# Altium schematic sheet Power.SchDoc(Power)
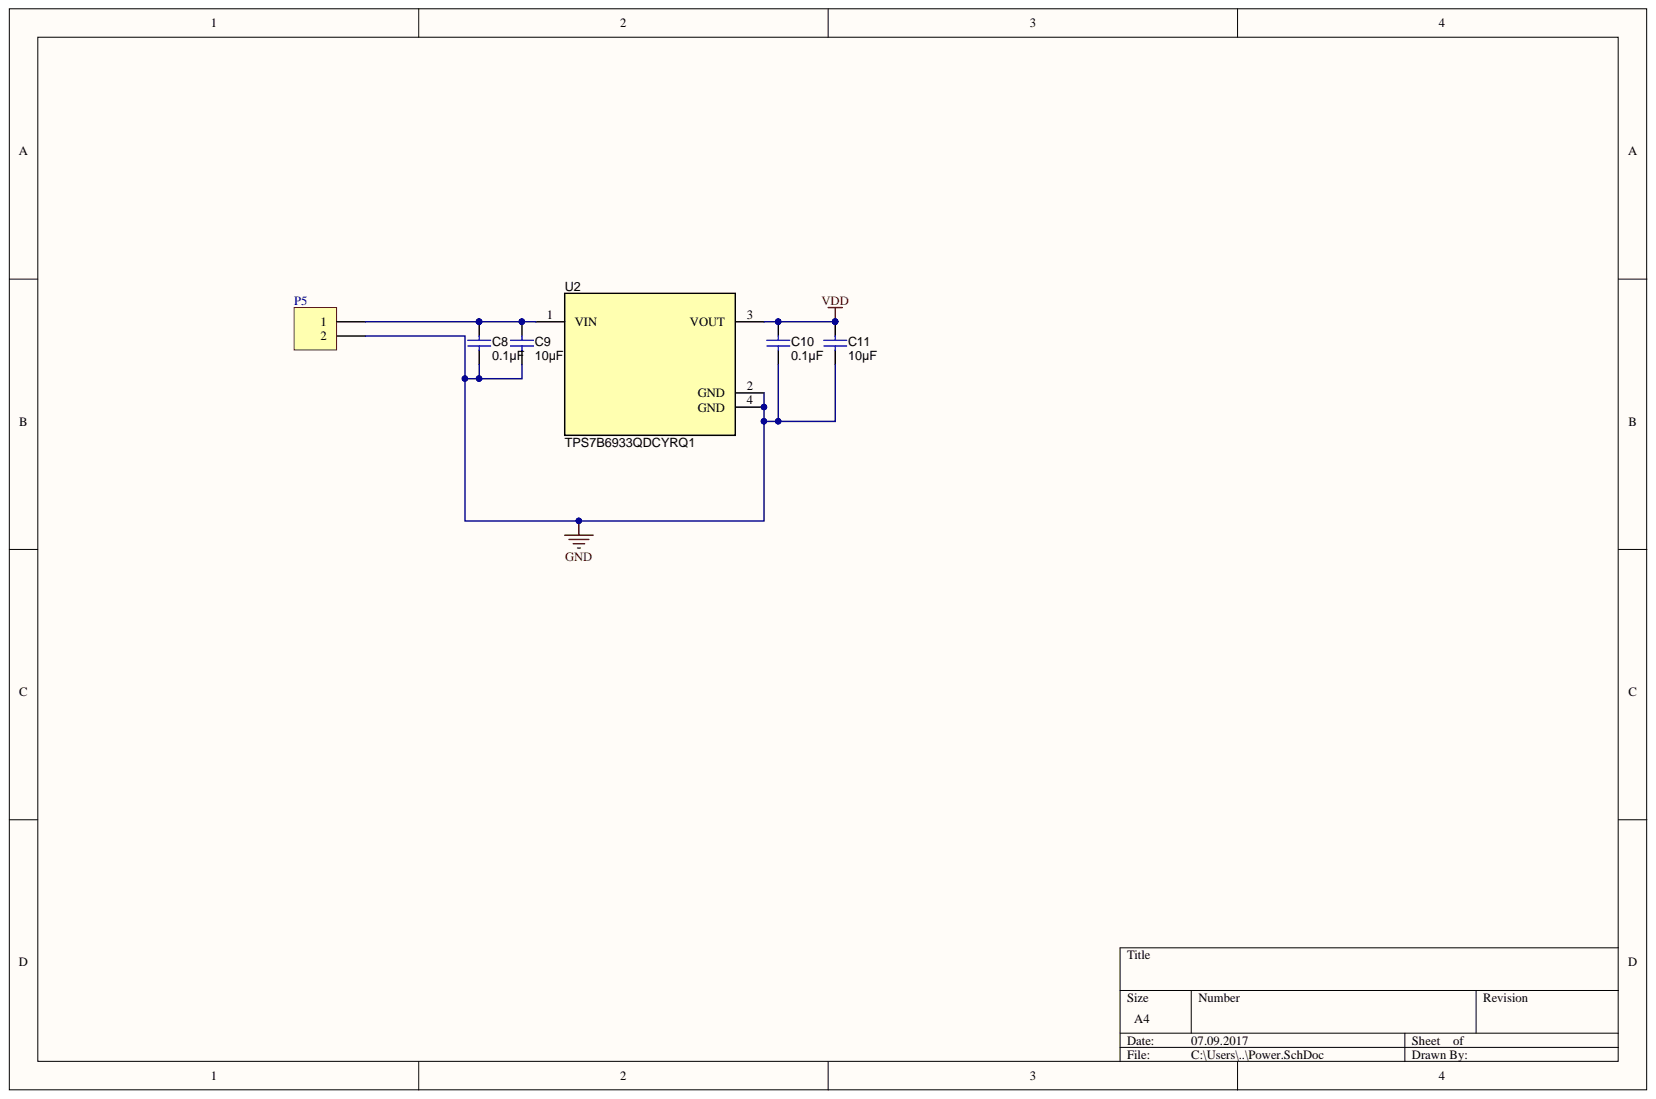
[diagram p0: Power.SchDoc]

## Parts
C8  ValueDisplayed: 0.1µF  [0603L]  (hidden comment: C0603C104J4RACTU)
C9  ValueDisplayed: 10µF  [1210L]  (hidden comment: GRM32ER71H106KA12L)
C10  ValueDisplayed: 0.1µF  [0603L]  (hidden comment: C0603C104J4RACTU)
C11  ValueDisplayed: 10µF  [1210L]  (hidden comment: GRM32ER71H106KA12L)
P5  (no value)  [HDR1X2]
U2  TPS7B6933QDCYRQ1  [DCY0004A_N]

## Nets
GND: C8.2 C9.2 C10.2 C11.2 P5.2 U2.2(GND) U2.4(GND)
VDD: C10.1 C11.1 U2.3(VOUT)
NetC8_1: C8.1 C9.1 P5.1 U2.1(VIN)

## Library data of the parts
C8 C10 = C0603C104J4RACTU: CAP, CERM, 0.1 µF, 16 V, +/- 5%, X7R, 0603; Manufacturer: Kemet; VDC_V: 16; Value2: 16V; Value1: Unknown; Value: 0.1uF; U/M: EA; Tolerance_%: 5; TaMin_C: -55; TaMax_C: 125; Polarized: N; CompType: Capacitor; Cap_F: 0.0000001; Cap_Case: 0603; AEC Category: Q200; TempCo: X7R; Technology: Ceramic; SymbolVersion: 2008-07-09; Supplier Part Number 3: 1650834; Supplier Part Number 2: 80-C0603C104J4R; Supplier Part Number 1: 399-1097-1-ND; Supplier 3: Farnell; Supplier 2: Mouser; Supplier 1: Digi-Key; Simulation: Cap; Signal Integrity: Cap; RoHS: Y; Published: 2008-06-19; PartNumber: C0603C104J4RACTU; PackageReference: 0603; MountType: SMT; Library Name: MDBU014A.SCHLIB; LatestRevisionDate: 2015-12-04; ComponentLink1URL: http://www.kemet.com/kemet/web/homepage/kechome.nsf/vapubfiles/F3102_Y5V.pdf/$file/F3102_Y5V.pdf; ComponentLink1Description: Datasheet link; Component Kind: Standard; AEC Qualified: ?; Publisher: Texas Instruments
C9 C11 = GRM32ER71H106KA12L: CAP, CERM, 10 µF, 50 V, +/- 10%, X7R, 1210; Manufacturer: MuRata; VDC_V: 50; Value2: 50V; Value1: Unknown; Value: 10uF; U/M: EA; Tolerance_%: 10; TaMin_C: -55; TaMax_C: 125; Polarized: N; CompType: Capacitor; Cap_F: 0.00001; Cap_Case: 1210; AEC Category: Q200; TempCo: X7R; Technology: Ceramic; SymbolVersion: 2008-07-09; Supplier Part Number 2: 81-GRM32ER71H106KA2L; Supplier Part Number 1: 490-6544-1-ND; Supplier 2: Mouser; Supplier 1: Digi-Key; Simulation: Cap; Signal Integrity: Cap; RoHS: Y; Published: 2008-06-19; PartNumber: GRM32ER71H106KA12L; PackageReference: 1210; MountType: SMT; Library Name: MDBU014A.SCHLIB; LatestRevisionDate: 2015-12-04; ComponentLink1URL: http://www.murata.com/products/catalog/pdf/c02e.pdf; ComponentLink1Description: Datasheet link; Component Kind: Standard; AEC Qualified: ?; Publisher: Texas Instruments
P5 = Header 2: Header, 2-Pin; Signal Integrity: Connector; Library Name: Miscellaneous Connectors.IntLib; LatestRevisionNote: Re-released for DXP Platform.; LatestRevisionDate: 17-Jul-2002; Component Kind: Standard; Publisher: Altium Limited
U2 = TPS7B6933QDCYRQ1: High Voltage Ultra Low Iq - Low Drop Out Regulator, DCY0004A; Manufacturer: Texas Instruments; U/M: EA; SymbolCreator: Altium; CompType: IC; SymbolVersion: 2014-08-05; PartNumber: TPS7B6933QDCYRQ1; PackageReference: DCY0004A; MountType: SMT; Library Name: MDBU014A.SCHLIB; LatestRevisionDate: 2015-12-29; ComponentLink1URL: http://www.ti.com/lit/ds/symlink/tps7b6933-q1.pdf; ComponentLink1Description: Datasheet Link; Component Kind: Standard; Alternate Manufacturer: Texas Instruments; Publisher: Texas Instruments
